# Altium schematic sheet Power_Tree.SchDoc
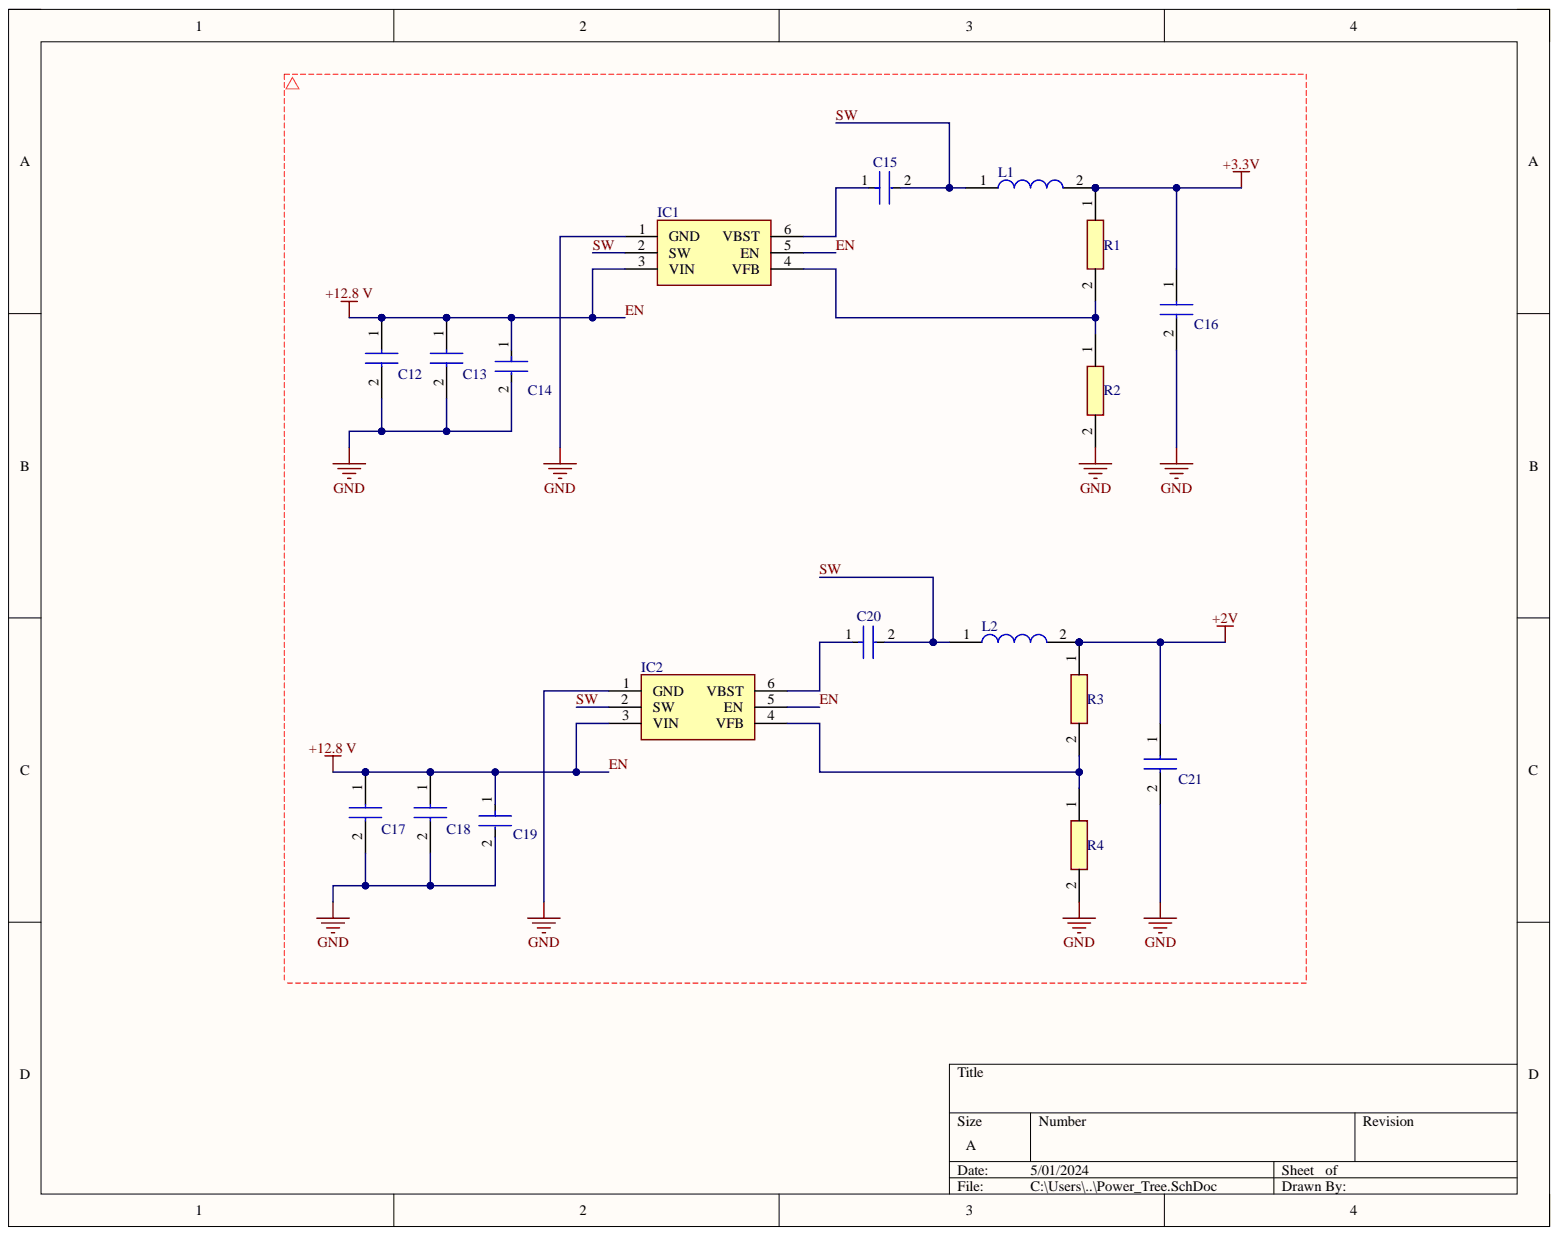
[diagram p0: Power_Tree.SchDoc]

## Parts
C12  GRM21BR61E106MA73L  [CAPC2012X145N]  (value not drawn)
C13  GRM21BR61E106MA73L  [CAPC2012X145N]  (value not drawn)
C14  CGA2B3X7R1E104K050BB  [FP-CGA2B-IPC_B]  (value not drawn)
C15  CGA2B3X7R1E104K050BB  [FP-CGA2B-IPC_B]  (value not drawn)
C16  C3216X5R1E476M160AC  [CAPC3216X180N]  (value not drawn)
C17  GRM21BR61E106MA73L  [CAPC2012X145N]  (value not drawn)
C18  GRM21BR61E106MA73L  [CAPC2012X145N]  (value not drawn)
C19  CGA2B3X7R1E104K050BB  [FP-CGA2B-IPC_B]  (value not drawn)
C20  CGA2B3X7R1E104K050BB  [FP-CGA2B-IPC_B]  (value not drawn)
C21  C3216X5R1E476M160AC  [CAPC3216X180N]  (value not drawn)
IC1  TPS561201DDCR  [SOT95P280X110-6N]  (value not drawn)
IC2  TPS561201DDCR  [SOT95P280X110-6N]  (value not drawn)
L1  74438335033  [INDC3030X150N]  (value not drawn)
L2  74438335033  [INDC3030X150N]  (value not drawn)
R1  CRCW040233K2FKED  [RESC1005X40N]  (value not drawn)
R2  CRCW040210K0FKED  [RESC1005X40N]  (value not drawn)
R3  CRCW040216K2FKED  [RESC1005X40N]  (value not drawn)
R4  CRCW040210K0FKED  [RESC1005X40N]  (value not drawn)

## Nets
+2V: C21.1 L2.2 R3.1
+3.3V: C16.1 L1.2 R1.1
GND: C12.2 C13.2 C14.2 C16.2 C17.2 C18.2 C19.2 C21.2 IC1.1(GND) IC2.1(GND) R2.2 R4.2
EN: C12.1 C13.1 C14.1 C17.1 C18.1 C19.1 IC1.3(VIN) IC1.5(EN) IC2.3(VIN) IC2.5(EN)
SW: C15.2 C20.2 IC1.2(SW) IC2.2(SW) L1.1 L2.1
NetC15_1: C15.1 IC1.6(VBST)
NetC20_1: C20.1 IC2.6(VBST)
NetIC1_4: IC1.4(VFB) R1.2 R2.1
NetIC2_4: IC2.4(VFB) R3.2 R4.1

## Library data of the parts
C12 C13 C17 C18 = GRM21BR61E106MA73L: Capacitor; Manufacturer_Name: Murata Electronics; Arrow Part Number: GRM21BR61E106MA73L; Arrow Price/Stock: https://www.arrow.com/en/products/grm21br61e106ma73l/murata-manufacturing?region=nac; Component Kind: Standard; Datasheet Link: http://www.murata.com/~/media/webrenewal/support/library/catalog/products/capacitor/mlcc/c02e.pdf; Height: 1.45mm; Manufacturer_Part_Number: GRM21BR61E106MA73L; Mouser Part Number: 81-GRM21BR61E106MA3L; Mouser Price/Stock: https://www.mouser.co.uk/ProductDetail/Murata-Electronics/GRM21BR61E106MA73L?qs=2W5sgKM%2F371odLMyCilkag%3D%3D
C14 C15 C19 C20 = CMP-2000-06086-2: Cap Ceramic 100nF 25V X7R 10% Pad SMD 0402 +125°C Automotive T/R; Capacitance: 100nF; Case Code (Imperial): 0402; Case Code (Metric): 1005; Case/Package: 0402; Component Kind: Standard; Composition: Ceramic; Depth: 0.5mm; Dielectric: X7R; Height: 0.5mm; Lead Free: Lead Free; Length: 1mm; Library Name: Altium Content Vault; Max Operating Temperature: 125°C; Min Operating Temperature: -55°C; Mount: Surface Mount; Package Quantity: 1; Packaging: Tape and Reel; Ratings: AEC-Q200; REACH SVHC: No SVHC; Termination: SMD/SMT; Thickness: 0.022inch; Tolerance: 10%; Voltage: 25V; Voltage Rating: 25V; Voltage Rating (DC): 25V; Weight: 2.3E-05oz; Width: 0.02inch
C16 C21 = C3216X5R1E476M160AC: Capacitor; Manufacturer_Name: TDK; Arrow Part Number: C3216X5R1E476M160AC; Arrow Price/Stock: https://www.arrow.com/en/products/c3216x5r1e476m160ac/tdk?region=nac; Component Kind: Standard; Datasheet Link: https://product.tdk.com/info/en/catalog/datasheets/mlcc_commercial_midvoltage_en.pdf; Height: 1.8mm; Manufacturer_Part_Number: C3216X5R1E476M160AC; Mouser Part Number: 810-C3216X5R1E476M; Mouser Price/Stock: https://www.mouser.co.uk/ProductDetail/TDK/C3216X5R1E476M160AC?qs=NRhsANhppD%252BqapdVvBvu4Q%3D%3D
IC1 IC2 = TPS561201DDCR: Integrated Circuit; Manufacturer_Name: Texas Instruments; Arrow Part Number: TPS561201DDCR; Arrow Price/Stock: https://www.arrow.com/en/products/tps561201ddcr/texas-instruments?region=nac; Component Kind: Standard; Datasheet Link: http://www.ti.com/lit/gpn/tps561201; Height: 1.1mm; Manufacturer_Part_Number: TPS561201DDCR; Mouser Part Number: 595-TPS561201DDCR; Mouser Price/Stock: https://www.mouser.co.uk/ProductDetail/Texas-Instruments/TPS561201DDCR?qs=5aG0NVq1C4ySUOSRQSt9MQ%3D%3D
L1 L2 = 74438335033: Inductor; Manufacturer_Name: Wurth Elektronik; Component Kind: Standard; Datasheet Link: http://katalog.we-online.de/pbs/datasheet/74438335033.pdf; Height: 1.5mm; Manufacturer_Part_Number: 74438335033; Mouser Part Number: 710-74438335033; Mouser Price/Stock: https://www.mouser.com/Search/Refine.aspx?Keyword=710-74438335033
R1 = CRCW040233K2FKED: Resistor; Manufacturer_Name: Vishay; Arrow Part Number: CRCW040233K2FKED; Arrow Price/Stock: https://www.arrow.com/en/products/crcw040233k2fked/vishay; Component Kind: Standard; Datasheet Link: http://www.vishay.com/docs/20035/dcrcwe3.pdf; Height: 0.4mm; Library Name: SamacSys.SchLib; Manufacturer_Part_Number: CRCW040233K2FKED; Mouser Part Number: 71-CRCW0402-33.2K-E3; Mouser Price/Stock: https://www.mouser.co.uk/ProductDetail/Vishay-Dale/CRCW040233K2FKED?qs=TNtMkGKFKOxaNJ4fnQ3loQ%3D%3D
R2 R4 = CRCW040210K0FKED: Resistor; Manufacturer_Name: Vishay; Arrow Part Number: CRCW040210K0FKED; Arrow Price/Stock: https://www.arrow.com/en/products/crcw040210k0fked/vishay?region=nac; Component Kind: Standard; Datasheet Link: http://www.vishay.com/docs/20035/dcrcwe3.pdf; Height: 0.4mm; Library Name: SamacSys.SchLib; Manufacturer_Part_Number: CRCW040210K0FKED; Mouser Part Number: 71-CRCW0402-10K-E3; Mouser Price/Stock: https://www.mouser.co.uk/ProductDetail/Vishay-Dale/CRCW040210K0FKED?qs=rlE3Te1NifyN3e8vvxacSw%3D%3D
R3 = CRCW040216K2FKED: Resistor; Manufacturer_Name: Vishay; Arrow Part Number: CRCW040216K2FKED; Arrow Price/Stock: https://www.arrow.com/en/products/crcw040216k2fked/vishay; Component Kind: Standard; Datasheet Link: http://www.vishay.com/docs/20035/dcrcwe3.pdf; Height: 0.4mm; Manufacturer_Part_Number: CRCW040216K2FKED; Mouser Part Number: 71-CRCW0402-16.2K-E3; Mouser Price/Stock: https://www.mouser.co.uk/ProductDetail/Vishay-Dale/CRCW040216K2FKED?qs=QPqDnsKvzKe%2FMyvkbvxylQ%3D%3D
